# Altium schematic sheet StLink.SchDoc(StLink)
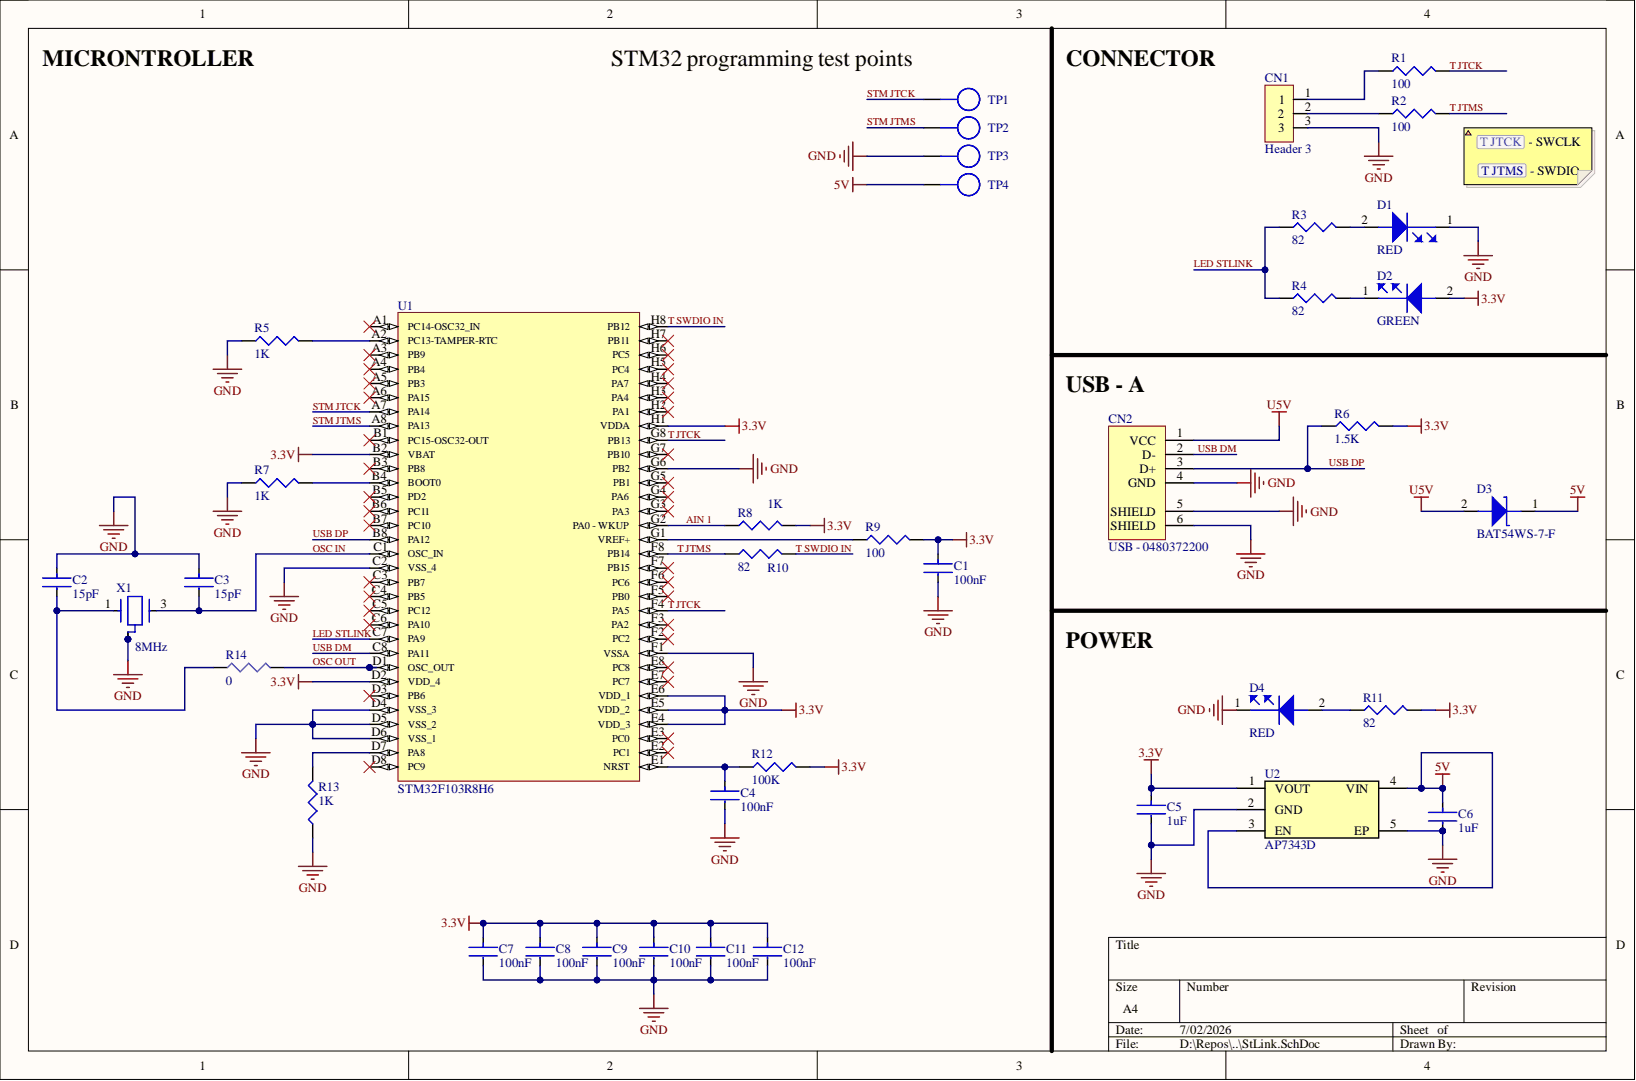
[diagram p0: StLink.SchDoc]

## Parts
C1  100nF  [C0201]
C2  15pF  [C0201]
C3  15pF  [C0201]
C4  100nF  [C0201]
C5  1uF  [C0201]
C6  1uF  [C0201]
C7  100nF  [C0201]
C8  100nF  [C0201]
C9  100nF  [C0201]
C10  100nF  [C0201]
C11  100nF  [C0201]
C12  100nF  [C0201]
CN1  Header 3  [H3x1]
CN2  USB - 0480372200  [usb A]
D1  RED  [Led 0402]
D2  GREEN  [Led 0402]
D3  BAT54WS-7-F  [SOD323]
D4  RED  [Led 0402]
R1  100  [R0201]
R2  100  [R0201]
R3  82  [R0201]
R4  82  [R0201]
R5  1K  [R0201]
R6  1.5K  [R0201]
R7  1K  [R0201]
R8  1K  [R0201]
R9  100  [R0201]
R10  82  [R0201]
R11  82  [R0201]
R12  100K  [R0201]
R13  1K  [R0201]
R14  0  [R0201]
TP1  Test_Point  [PAD]  (value not drawn)
TP2  Test_Point  [PAD]  (value not drawn)
TP3  Test_Point  [PAD]  (value not drawn)
TP4  Test_Point  [PAD]  (value not drawn)
U1  STM32F103R8H6  [Micro BGA]
U2  AP7343D  [AP7343D]
X1  8MHz  [Cristal ECS]

## Nets
3.3V: C1.1 C5.1 C7.1 C8.1 C9.1 C10.1 C11.1 C12.1 D2.2 R6.2 R8.2 R9.2 R11.2 R12.2 U1.B2(VBAT) U1.D2(VDD_4) U1.E4(VDD_3) U1.E5(VDD_2) U1.E6(VDD_1) U1.H1(VDDA) U2.1(VOUT)
5V: C6.1 D3.1 TP4.1 U2.3(EN) U2.4(VIN)
GND: C1.2 C2.1 C3.1 C4.2 C5.2 C6.2 C7.2 C8.2 C9.2 C10.2 C11.2 C12.2 CN1.3 CN2.4(GND) CN2.5(SHIELD) CN2.6(SHIELD) D1.1 D4.1 R5.1 R7.1 R13.1 TP3.1 U1.C2(VSS_4) U1.D4(VSS_3) U1.D5(VSS_2) U1.D6(VSS_1) U1.F1(VSSA) U1.G6(PB2) U2.2(GND) U2.5(EP) X1.2 X1.4
U5V: CN2.1(VCC) D3.2
AIN 1: R8.1 U1.G2(PA0_-_WKUP)
LED STLINK: R3.1 R4.1 U1.C7(PA9)
OSC IN: C3.2 U1.C1(OSC_IN) X1.3
OSC OUT: R14.2 U1.D1(OSC_OUT)
STM JTCK: TP1.1 U1.A7(PA14)
STM JTMS: TP2.1 U1.A8(PA13)
T JTCK: R1.2 U1.F4(PA5) U1.G8(PB13)
T JTMS: R2.2 R10.1 U1.F8(PB14)
T SWDIO IN: R10.2 U1.H8(PB12)
USB DM: CN2.2(D-) U1.C8(PA11)
USB DP: CN2.3(D+) R6.1 U1.B8(PA12)
NetC2_2: C2.2 R14.1 X1.1
NetC4_1: C4.1 R12.1 U1.E1(NRST)
NetCN1_1: CN1.1 R1.1
NetCN1_2: CN1.2 R2.1
NetD1_2: D1.2 R3.2
NetD2_1: D2.1 R4.2
NetD4_2: D4.2 R11.1
NetR5_2: R5.2 U1.A2(PC13-TAMPER-RTC)
NetR7_2: R7.2 U1.B4(BOOT0)
NetR9_1: R9.1 U1.G1(VREF+)
NetR13_2: R13.2 U1.D7(PA8)

Not connected: U1 A1(PC14-OSC32_IN) A3(PB9) A4(PB4) A5(PB3) A6(PA15) B1(PC15-OSC32-OUT) B3(PB8) B5(PD2) B6(PC11) B7(PC10) C3(PB7) C4(PB5) C5(PC12) C6(PA10) D3(PB6) D8(PC9) E2(PC1) E3(PC0) E7(PC7) E8(PC8) F2(PC2) F3(PA2) F5(PB0) F6(PC6) F7(PB15) G3(PA3) G4(PA6) G5(PB1) G7(PB10) H2(PA1) H3(PA4) H4(PA7) H5(PC4) H6(PC5) H7(PB11)
